# Altium schematic sheet UCC14240 Test Board.SchDoc
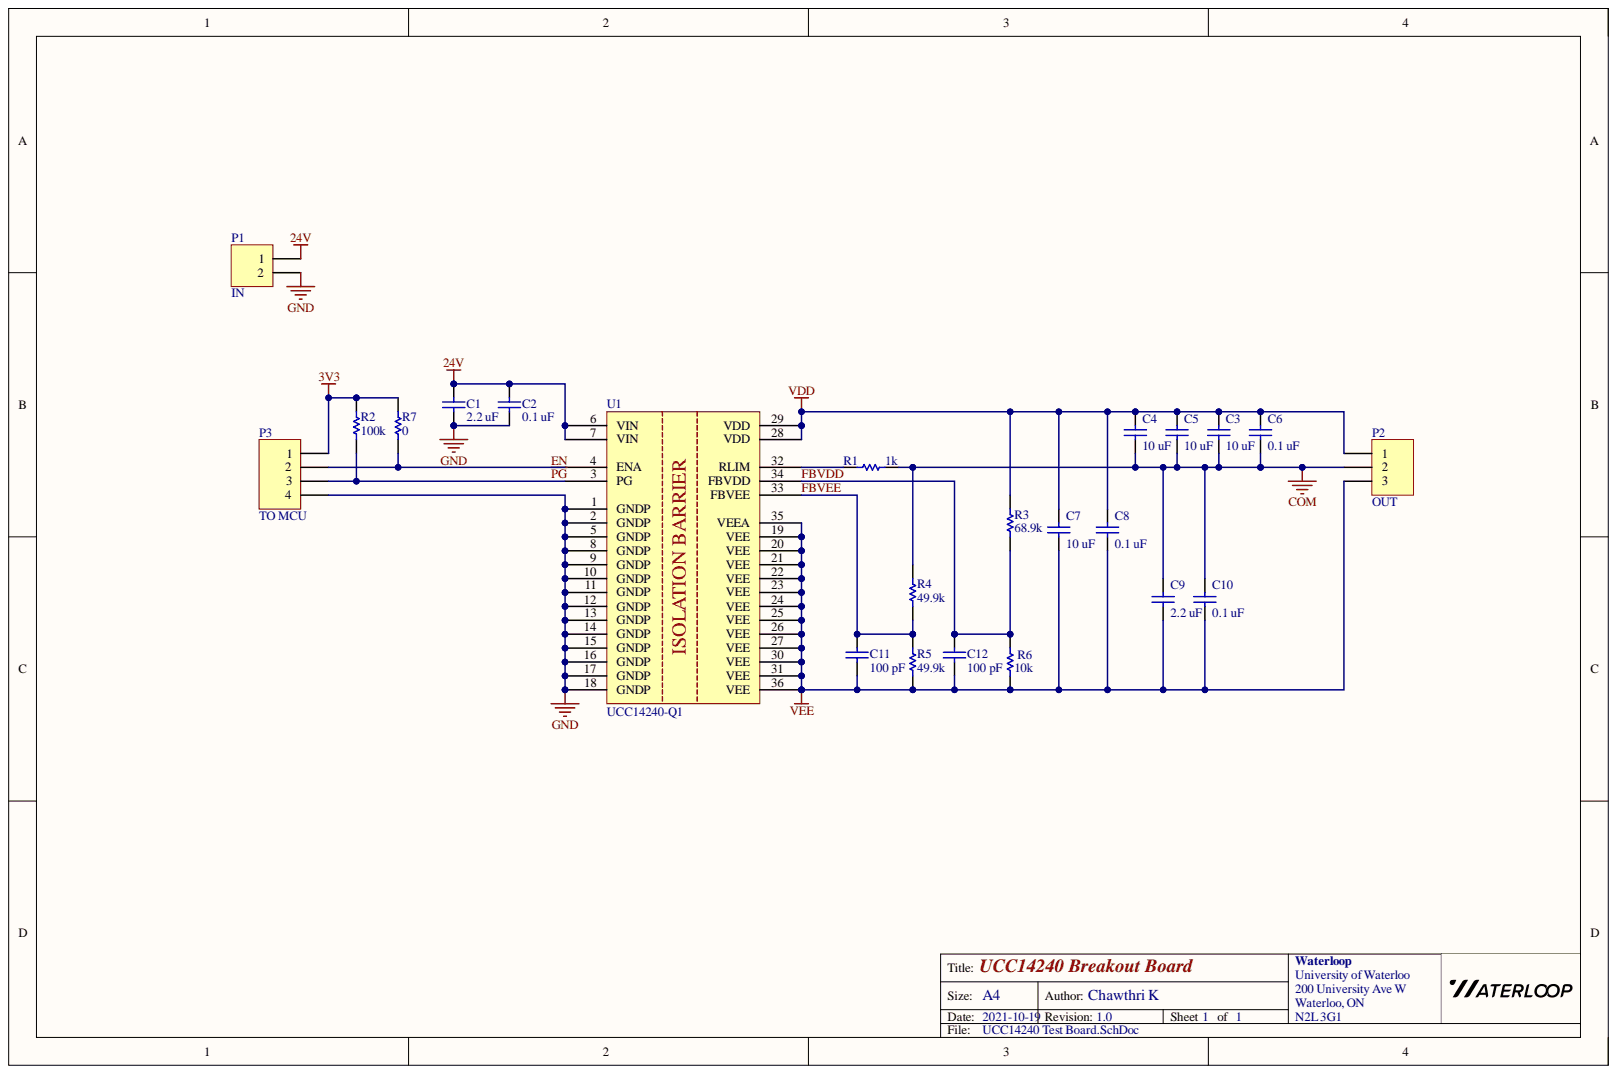
[diagram p0: UCC14240 Test Board.SchDoc]

## Parts
C1  value 2.2 uF  [0805 - Capacitor]  (hidden comment: Capacitor - Nonpolarized)
C2  value 0.1 uF  [0805 - Capacitor]  (hidden comment: Capacitor - Nonpolarized)
C3  value 10 uF  [0805 - Capacitor]  (hidden comment: Capacitor - Nonpolarized)
C4  value 10 uF  [0805 - Capacitor]  (hidden comment: Capacitor - Nonpolarized)
C5  value 10 uF  [0805 - Capacitor]  (hidden comment: Capacitor - Nonpolarized)
C6  value 0.1 uF  [0805 - Capacitor]  (hidden comment: Capacitor - Nonpolarized)
C7  value 10 uF  [0805 - Capacitor]  (hidden comment: Capacitor - Nonpolarized)
C8  value 0.1 uF  [0805 - Capacitor]  (hidden comment: Capacitor - Nonpolarized)
C9  value 2.2 uF  [0805 - Capacitor]  (hidden comment: Capacitor - Nonpolarized)
C10  value 0.1 uF  [0805 - Capacitor]  (hidden comment: Capacitor - Nonpolarized)
C11  value 100 pF  [0603 - Capacitor]  (hidden comment: Capacitor - Nonpolarized)
C12  value 100 pF  [0603 - Capacitor]  (hidden comment: Capacitor - Nonpolarized)
P1  IN  [HDR1X2]
P2  OUT  [HDR1X3]
P3  TO MCU  [HDR1X4]
R1  value 1k  [0805 - Resistor]  (hidden comment: Resistor)
R2  value 100k  [0603 - Resistor]  (hidden comment: Resistor)
R3  value 68.9k  [0603 - Resistor]  (hidden comment: Resistor)
R4  value 49.9k  [0603 - Resistor]  (hidden comment: Resistor)
R5  value 49.9k  [0603 - Resistor]  (hidden comment: Resistor)
R6  value 10k  [0603 - Resistor]  (hidden comment: Resistor)
R7  value 0  [0603 - Resistor]  (hidden comment: Resistor)
U1  UCC14240-Q1  [SOP65P1020X355-36N]

## Nets
3V3: P3.1 R2.2 R7.2
24V: C1.2 C2.2 P1.1 U1.6(VIN) U1.7(VIN)
COM: C3.1 C4.1 C5.1 C6.1 C9.2 C10.2 P2.2 R1.2 R4.2
GND: C1.1 C2.1 P1.2 P3.4 U1.1(GNDP) U1.2(GNDP) U1.5(GNDP) U1.8(GNDP) U1.9(GNDP) U1.10(GNDP) U1.11(GNDP) U1.12(GNDP) U1.13(GNDP) U1.14(GNDP) U1.15(GNDP) U1.16(GNDP) U1.17(GNDP) U1.18(GNDP)
VDD: C3.2 C4.2 C5.2 C6.2 C7.2 C8.2 P2.1 R3.2 U1.28(VDD) U1.29(VDD)
VEE: C7.1 C8.1 C9.1 C10.1 C11.1 C12.1 P2.3 R5.1 R6.1 U1.19(VEE) U1.20(VEE) U1.21(VEE) U1.22(VEE) U1.23(VEE) U1.24(VEE) U1.25(VEE) U1.26(VEE) U1.27(VEE) U1.30(VEE) U1.31(VEE) U1.35(VEEA) U1.36(VEE)
EN: P3.2 R7.1 U1.4(ENA)
FBVDD: C12.2 R3.1 R6.2 U1.34(FBVDD)
FBVEE: C11.2 R4.1 R5.2 U1.33(FBVEE)
~{PG}: P3.3 R2.1 U1.3(PG)
NetR1_1: R1.1 U1.32(RLIM)

## Library data of the parts
C1 C9 = Capacitor - Nonpolarized: Nonpolarized Capacitor; Value: 2.2 uF; Component Kind: Standard; Library Name: General.SchLib; Signal Integrity: Cap; Simulation: CAP
C2 C3 C5 C6 C8 C10 C12 = Capacitor - Nonpolarized: Nonpolarized Capacitor; Component Kind: Standard; Library Name: General.SchLib; Signal Integrity: Cap; Simulation: CAP
C4 C7 = Capacitor - Nonpolarized: Nonpolarized Capacitor; Value: 10 uF; Component Kind: Standard; Library Name: General.SchLib; Signal Integrity: Cap; Simulation: CAP
C11 = Capacitor - Nonpolarized: Nonpolarized Capacitor; Value: 100 pF; Component Kind: Standard; Library Name: General.SchLib; Signal Integrity: Cap; Simulation: CAP
P1 = 1x2 Header: 1x2 Pin Header; Component Kind: Standard; Library Name: GeneralConnectors.SchLib; Signal Integrity: Connector
P2 = 1x3 Header: 1x3 Pin Header; Component Kind: Standard; Library Name: GeneralConnectors.SchLib; Signal Integrity: Connector
P3 = 1x4 Header: 1x4 Pin Header; Component Kind: Standard; Library Name: GeneralConnectors.SchLib; Signal Integrity: Connector
U1 = UCC14240-Q1: Isolated DC/DC Converter with Integrated Transformer; Manufacturer: Texas Instruments; Component Kind: Standard; ComponentLink1Description: Datasheet; ComponentLink1URL: https://www.ti.com/lit/ds/symlink/ucc14240-q1.pdf?ts=1634091484132&ref_url=https%253A%252F%252Fwww.ti.com%252Fproduct%252FUCC14240-Q1; Library Name: MC.SchLib; MPN: UCC14240-Q1
R1-R7 = Resistor: Component Kind: Standard; Description: Resistor; Library Name: General.SchLib; Signal Integrity: Res; Simulation: RESISTOR
